# Altium schematic sheet Break Light Schematic.SchDoc
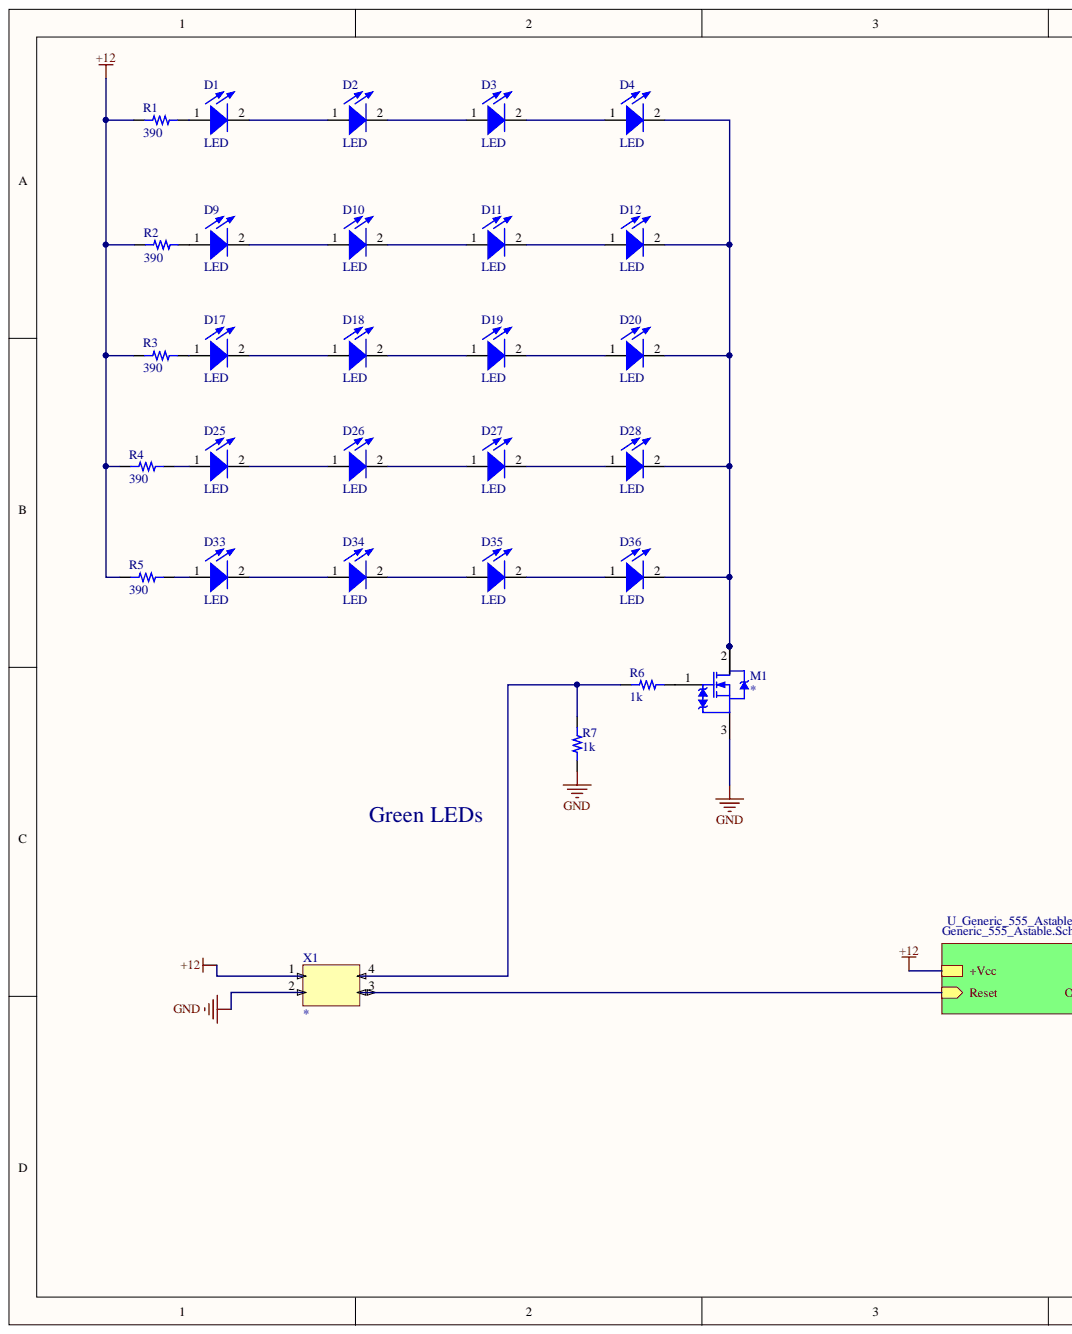
[diagram p0: Break Light Schematic.SchDoc, left]
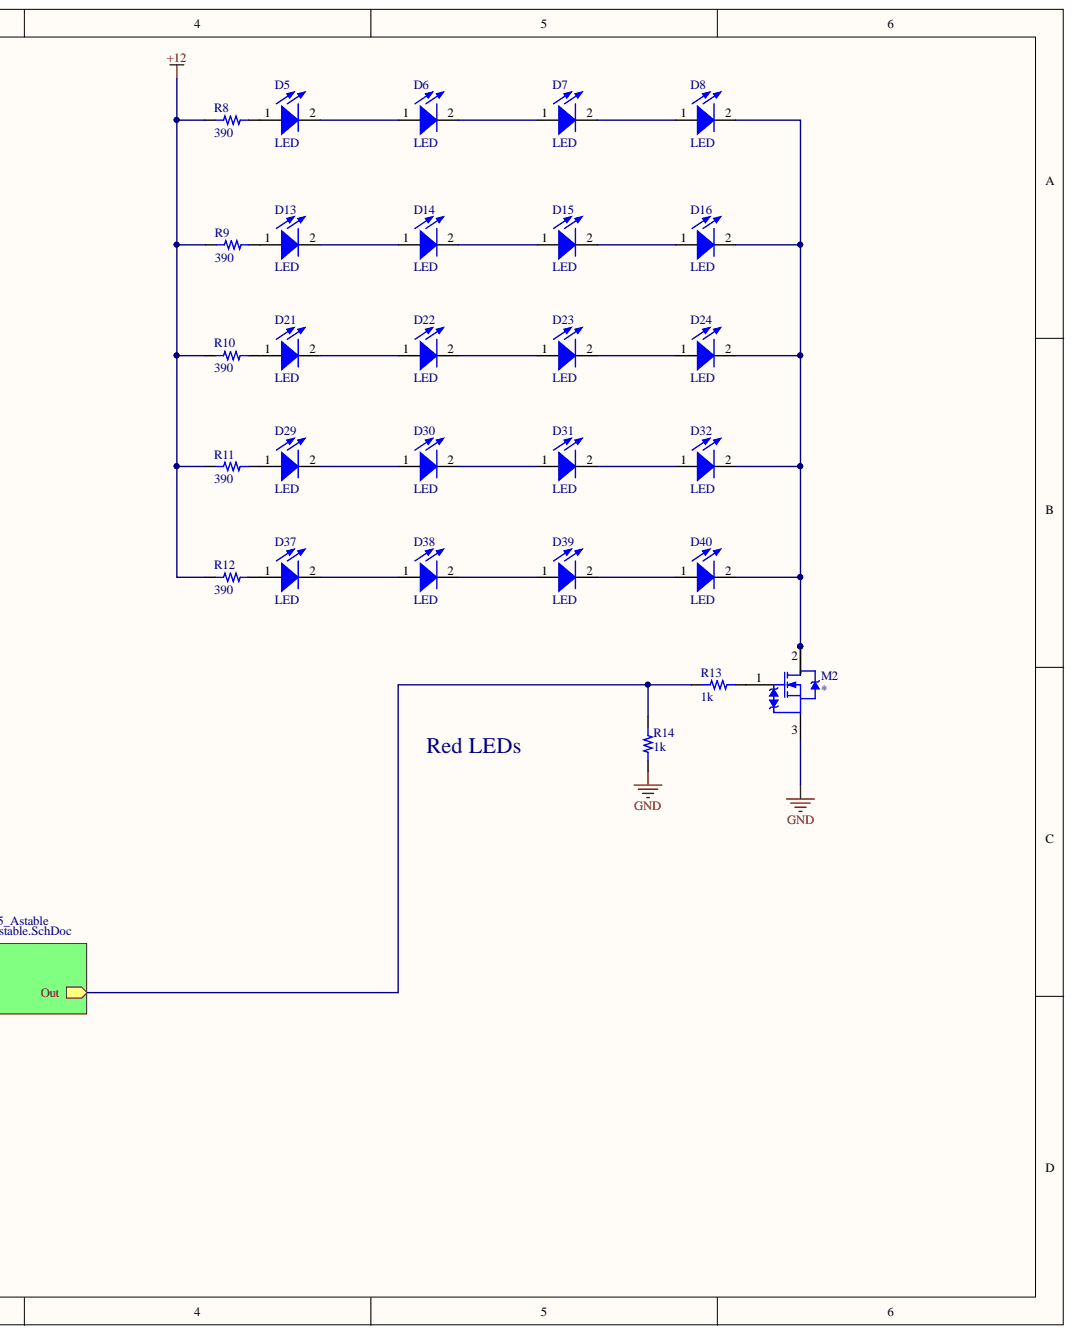
[diagram p1: Break Light Schematic.SchDoc, right]

## Parts
D1  LED  [LED TH]
D2  LED  [LED TH]
D3  LED  [LED TH]
D4  LED  [LED TH]
D5  LED  [LED TH]
D6  LED  [LED TH]
D7  LED  [LED TH]
D8  LED  [LED TH]
D9  LED  [LED TH]
D10  LED  [LED TH]
D11  LED  [LED TH]
D12  LED  [LED TH]
D13  LED  [LED TH]
D14  LED  [LED TH]
D15  LED  [LED TH]
D16  LED  [LED TH]
D17  LED  [LED TH]
D18  LED  [LED TH]
D19  LED  [LED TH]
D20  LED  [LED TH]
D21  LED  [LED TH]
D22  LED  [LED TH]
D23  LED  [LED TH]
D24  LED  [LED TH]
D25  LED  [LED TH]
D26  LED  [LED TH]
D27  LED  [LED TH]
D28  LED  [LED TH]
D29  LED  [LED TH]
D30  LED  [LED TH]
D31  LED  [LED TH]
D32  LED  [LED TH]
D33  LED  [LED TH]
D34  LED  [LED TH]
D35  LED  [LED TH]
D36  LED  [LED TH]
D37  LED  [LED TH]
D38  LED  [LED TH]
D39  LED  [LED TH]
D40  LED  [LED TH]
M1  (no value)  [SOT-223-3]
M2  (no value)  [SOT-223-3]
R1  390  [RES 0603/1608]
R2  390  [RES 0603/1608]
R3  390  [RES 0603/1608]
R4  390  [RES 0603/1608]
R5  390  [RES 0603/1608]
R6  1k  [RES 0603/1608]
R7  1k  [RES 0603/1608]
R8  390  [RES 0603/1608]
R9  390  [RES 0603/1608]
R10  390  [RES 0603/1608]
R11  390  [RES 0603/1608]
R12  390  [RES 0603/1608]
R13  1k  [RES 0603/1608]
R14  1k  [RES 0603/1608]
X1  (no value)  [DT15-4P]

## Nets
+12: R1.1 R2.1 R3.1 R4.1 R5.1 R8.1 R9.1 R10.1 R11.1 R12.1 X1.1
GND: M1.3 M2.3 R7.1 R14.1 X1.2
NetD1_1: D1.1 R1.2
NetD1_2: D1.2 D2.1
NetD2_2: D2.2 D3.1
NetD3_2: D3.2 D4.1
NetD4_2: D4.2 D12.2 D20.2 D28.2 D36.2 M1.2 M1.THM
NetD5_1: D5.1 R8.2
NetD5_2: D5.2 D6.1
NetD6_2: D6.2 D7.1
NetD7_2: D7.2 D8.1
NetD8_2: D8.2 D16.2 D24.2 D32.2 D40.2 M2.2 M2.THM
NetD9_1: D9.1 R2.2
NetD9_2: D9.2 D10.1
NetD10_2: D10.2 D11.1
NetD11_2: D11.2 D12.1
NetD13_1: D13.1 R9.2
NetD13_2: D13.2 D14.1
NetD14_2: D14.2 D15.1
NetD15_2: D15.2 D16.1
NetD17_1: D17.1 R3.2
NetD17_2: D17.2 D18.1
NetD18_2: D18.2 D19.1
NetD19_2: D19.2 D20.1
NetD21_1: D21.1 R10.2
NetD21_2: D21.2 D22.1
NetD22_2: D22.2 D23.1
NetD23_2: D23.2 D24.1
NetD25_1: D25.1 R4.2
NetD25_2: D25.2 D26.1
NetD26_2: D26.2 D27.1
NetD27_2: D27.2 D28.1
NetD29_1: D29.1 R11.2
NetD29_2: D29.2 D30.1
NetD30_2: D30.2 D31.1
NetD31_2: D31.2 D32.1
NetD33_1: D33.1 R5.2
NetD33_2: D33.2 D34.1
NetD34_2: D34.2 D35.1
NetD35_2: D35.2 D36.1
NetD37_1: D37.1 R12.2
NetD37_2: D37.2 D38.1
NetD38_2: D38.2 D39.1
NetD39_2: D39.2 D40.1
NetM1_1: M1.1 R6.2
NetM2_1: M2.1 R13.2
NetR6_1: R6.1 R7.2 X1.4
NetR13_1: R13.1 R14.2
NetX1_3: X1.3

## Library data of the parts
D1-D40 = 23: Thru Hole LED; Database Table Name: diodes; Component Kind: Standard; id: 23; Library Name: altlib.DbLib
M1 M2 = 20: N-Channel 450V 600mA (Tc) 3W (Ta) Surface Mo; current: 0.6A; Database Table Name: fets; name: STN3N45K3; numcircuits: 1; rdson: 3.3Ohm; vdsmax: 0; vgsmax: 30; vt: 3.75; Component Kind: Standard; id: 20; link: https://www.digikey.com/product-detail/en/stmicroelectronics/STN3N45K3/497-10649-1-ND/2346728; package: SOT-223-3; quantity: 0; type: N_Channel; Library Name: altlib.DbLib
R1-R14 = 1: Database Table Name: resistors; name: 0603 Resistor; percent tolerance: 5; Component Kind: Standard; id: 1; package: 0603; quantity: 0; Library Name: altlib.DbLib
  R1-R5 R8-R12: resistance: 390
  R6 R7 R13 R14: resistance: 1k
X1 = 32: DT15-4P Connector for Brakelight; Database Table Name: connectors; numcontacts: 4; numrows: 2; pitch: 9.12mm; Component Kind: Standard; id: 32; link: https://www.te.com/usa-en/product-DT15-4P.html?source=header-match; name: DT15-4P; quantity: 0; createdby: Andrew; Library Name: altlib.DbLib
